# Altium schematic sheet 1_Power.SchDoc
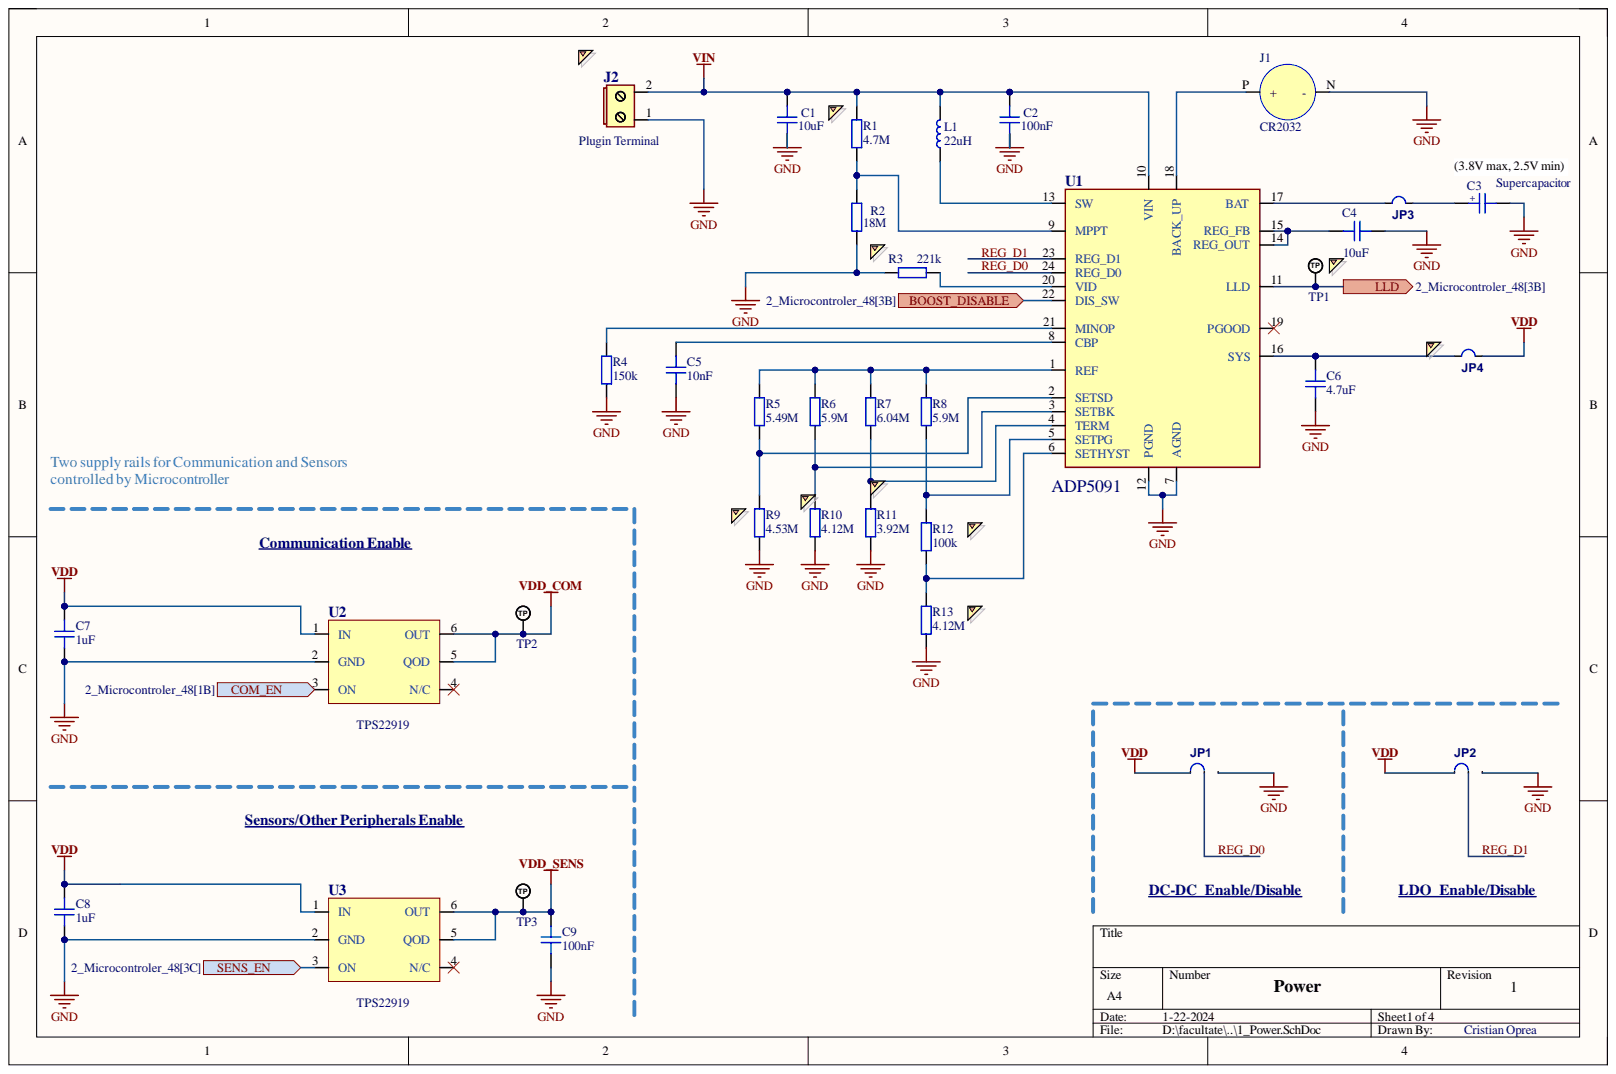
[diagram p0: 1_Power.SchDoc]

## Parts
C1  10uF  [C0603]
C2  100nF  [C0603]
C3  Supercapacitor  [CAP_POL_8x16x3.5]
C4  10uF  [C0603]
C5  10nF  [C0603]
C6  4.7uF  [C0603]
C7  1uF  [C0603]
C8  1uF  [C0603]
C9  100nF  [C0603]
J1  CR2032  [BAT_CR2032-BS-6-1]
J2  Plugin Terminal  [2.54_pluggable_terminal]
JP1  JUMPER_3PIN  [HEADER_1x3_2.54]  (value not drawn)  (jumper)
JP2  JUMPER_3PIN  [HEADER_1x3_2.54]  (value not drawn)  (jumper)
JP3  JUMPER  [HEADER_1x2_2.54]  (value not drawn)
JP4  JUMPER  [HEADER_1x2_2.54]  (value not drawn)
L1  22uH  [IND_3.2_4]
R1  4.7M  [R0603]
R2  18M  [R0603]
R3  221k  [R0603]
R4  150k  [R0603]
R5  5.49M  [R0603]
R6  5.9M  [R0603]
R7  6.04M  [R0603]
R8  5.9M  [R0603]
R9  4.53M  [R0603]
R10  4.12M  [R0603]
R11  3.92M  [R0603]
R12  100k  [R0603]
R13  4.12M  [R0603]
TP1  TP_NOBOM  [TEST_PAD_THD]  (value not drawn)  (no BOM)
TP2  TP_NOBOM  [TEST_PAD_THD]  (value not drawn)  (no BOM)
TP3  TP_NOBOM  [TEST_PAD_THD]  (value not drawn)  (no BOM)
U1  ADP5091  [LFCSP-24]
U2  TPS22919  [SC70-6]
U3  TPS22919  [SC70-6]

## Ports
BOOST_DISABLE, COM_EN, LLD, SENS_EN

## Nets
GND: C1.1 C2.1 C3.2 C4.2 C5.1 C6.1 C7.1 C8.1 C9.1 J1.N J2.1 JP1.3 JP2.3 R2.1 R3.1 R4.1 R9.1 R10.1 R11.1 R13.1 U1.7(AGND) U1.12(PGND) U2.2(GND) U3.2(GND)
VDD: C7.2 C8.2 JP1.1 JP2.1 JP4.2 U2.1(IN) U3.1(IN)
VDD_COM: TP2.1 U2.5(QOD) U2.6(OUT)
VDD_SENS: C9.2 TP3.1 U3.5(QOD) U3.6(OUT)
VIN: C1.2 C2.2 J2.2 L1.2 R1.2 U1.10(VIN)
BOOST_DISABLE: U1.22(DIS_SW) ; port BOOST_DISABLE
COM_EN: U2.3(ON) ; port COM_EN
LLD: TP1.1 U1.11(LLD) ; port LLD
REG_D0: JP1.2 U1.24(REG_D0)
REG_D1: JP2.2 U1.23(REG_D1)
SENS_EN: U3.3(ON) ; port SENS_EN
NetC3_1: C3.1 JP3.2
NetC4_1: C4.1 U1.14(REG_OUT) U1.15(REG_FB)
NetC5_2: C5.2 U1.8(CBP)
NetC6_2: C6.2 JP4.1 U1.16(SYS)
NetJ1_P: J1.P U1.18(BACK_UP)
NetJP3_1: JP3.1 U1.17(BAT)
NetL1_1: L1.1 U1.13(SW)
NetR1_1: R1.1 R2.2 U1.9(MPPT)
NetR3_2: R3.2 U1.20(VID)
NetR4_2: R4.2 U1.21(MINOP)
NetR5_1: R5.1 R9.2 U1.2(SETSD)
NetR5_2: R5.2 R6.2 R7.2 R8.2 U1.1(REF)
NetR6_1: R6.1 R10.2 U1.3(SETBK)
NetR7_1: R7.1 R11.2 U1.4(TERM)
NetR8_1: R8.1 R12.2 U1.5(SETPG)
NetR12_1: R12.1 R13.2 U1.6(SETHYST)

Not connected: U1 19(PGOOD); U2 4(N/C); U3 4(N/C)

## Library data of the parts
C1 C2 C4-C9 = CAP_0603: Capacitor SMD 0603; Component Kind: Standard; Library Name: Schlib_H2.SchLib
J1 = CR2032-BS-6-1: CR2032 Coin Cell Battery Holder; Manufacturer: Q&J; Component Kind: Standard; Library Name: Schlib_H2.SchLib; Manufacturer Part Number: CR2032-BS-6-1
J2 = Power_Connector: Screw terminal or plug terminal for power; Component Kind: Standard; Library Name: Schlib_H2.SchLib
L1 = IND_1008: Inductor SMD 1008; Component Kind: Standard; Library Name: Schlib_H2.SchLib
R1-R13 = RES_0603: Resistor SMD 0603; Component Kind: Standard; Library Name: Schlib_H2.SchLib
TP1-TP3 = TEST_POINT_RND_1MM: Test Point Round 1mm (NO BOM); Library Name: Schlib_H2.SchLib
U1 = ADP5091: Ultralow Power Energy Harvester PMUs   with MPPT and Charge Management and BAckup Supply; Component Kind: Standard; Library Name: Schlib_H2.SchLib
U2 U3 = TPS22919: 5.5-V, 1.5-A, 90-mΩ load switch with adjustable output discharge; Component Kind: Standard; Library Name: Schlib_H2.SchLib
C3 = Supercapacitor: Component Kind: Standard; Description: Supercapacitor; Library Name: Schlib_H2.SchLib
JP1 JP2 = JUMPER_3PIN: Library Name: Schlib_H2.SchLib
JP3 JP4 = JUMPER: Component Kind: Standard; Library Name: Schlib_H2.SchLib
